# Altium schematic sheet Sheet1.SchDoc(Sheet1)
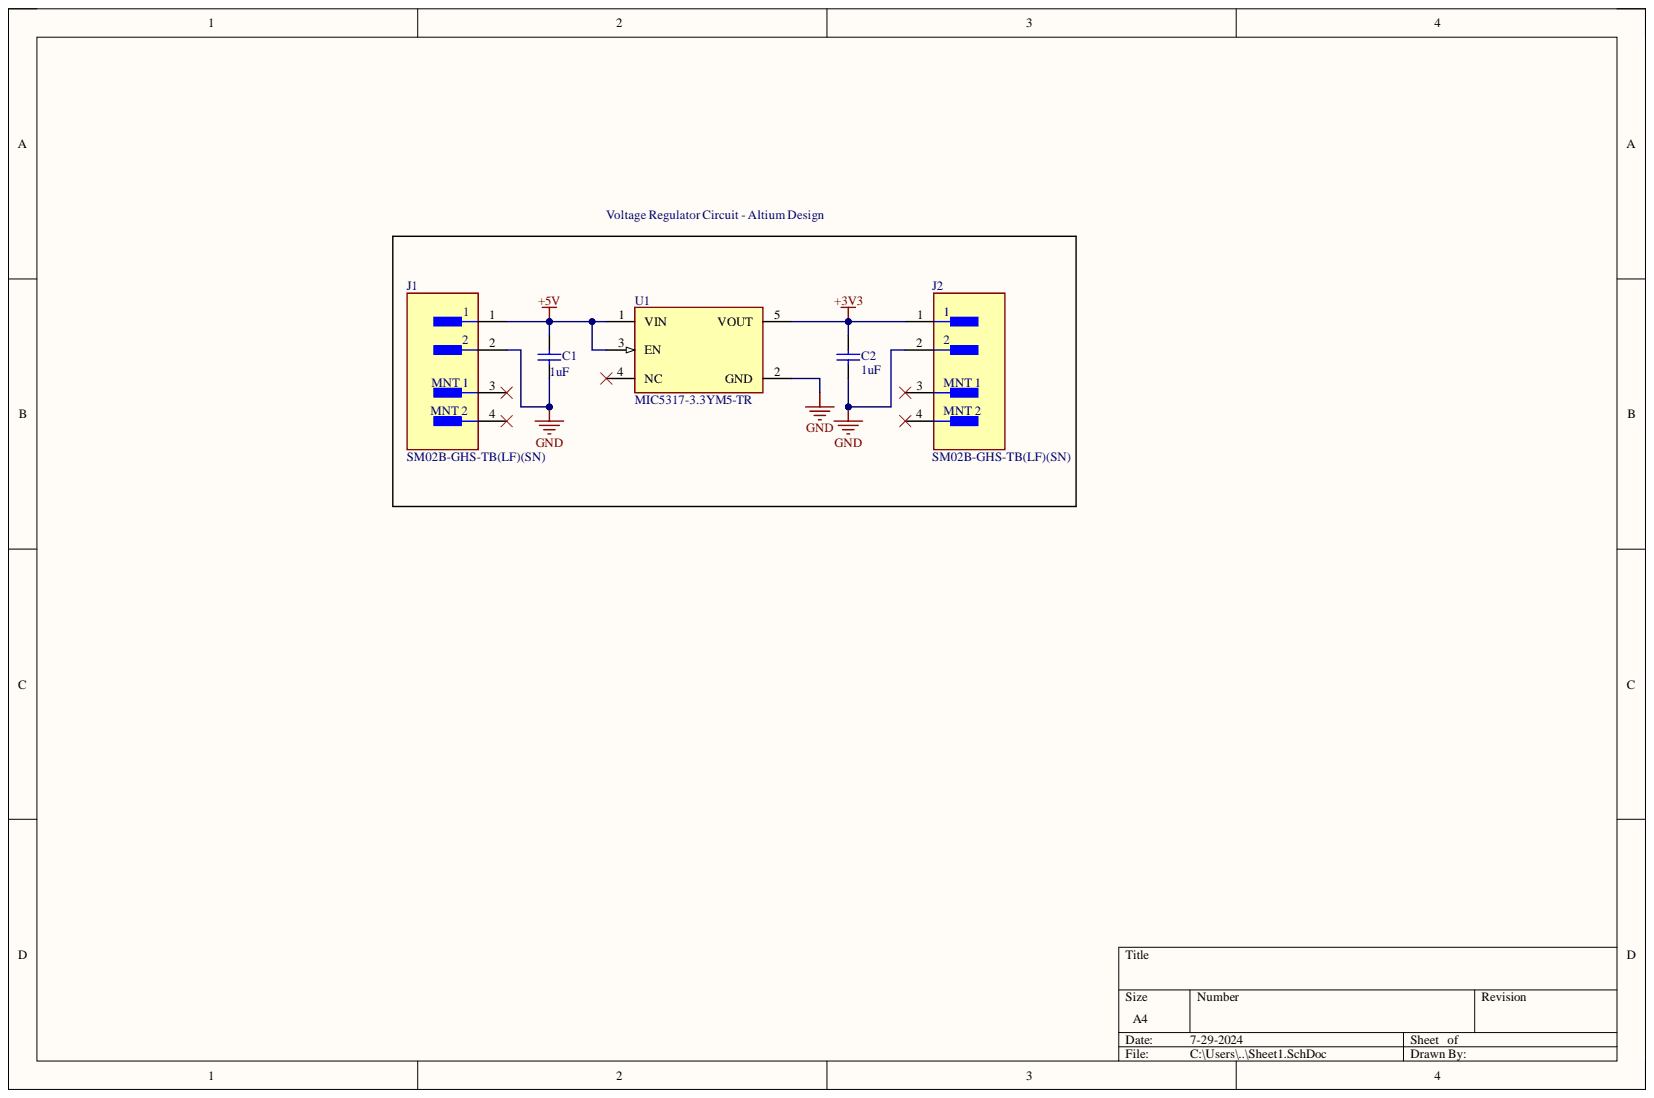
[diagram p0: Sheet1.SchDoc]

## Parts
C1  1uF  [CAPC2013X135X45LL10T25]
C2  1uF  [CAPC2013X135X45LL10T25]
J1  SM02B-GHS-TB(LF)(SN)  [FP-SM02B-GHS-TB_LF_SN-MFG]
J2  SM02B-GHS-TB(LF)(SN)  [FP-SM02B-GHS-TB_LF_SN-MFG]
U1  MIC5317-3.3YM5-TR  [FP-SOT23-5LD-PL-1-MFG]

## Nets
+3V3: C2.2 J2.1 U1.5(VOUT)
+5V: C1.2 J1.1 U1.1(VIN) U1.3(EN)
GND: C1.1 C2.1 J1.2 J2.2 U1.2(GND)

Not connected: J1 3 4; J2 3 4; U1 4(NC)

## Library data of the parts
C1 C2 = CMP-1036-04761-2: Manufacturer: Murata; Part Number: GRM21BR71H105KA12L; Component Kind: Standard; Library Name: Altium Content Vault
J1 J2 = CMP-2000-07076-2: CONN HEADER SMD R/A 2POS 1.25MM; Connector Type: Outer Shroud Contact; Current Rating: 1A; Number of Contacts: 2; Pitch: 0.049inch; Voltage Rating: 50V; Color: Natural; Component Kind: Standard; Contact Material: Bronze; Contact Plating: Tin; Contact Type: Male Pin; Fastening Type: Latch; Housing Color: Natural; Housing Material: Nylon; Lead Free: Lead Free; Library Name: Altium Content Vault; Mount: Surface Mount; Number of Positions: 2; Number of Rows: 1; Orientation: Right Angle; Package Quantity: 2500; Packaging: Tape and Reel; Series: GH; Termination: Solder
U1 = CMP-06324-000116-1: IC REG LINEAR 3.3V 150MA SOT23-5; Dropout Voltage: 155mV; Max Input Voltage: 6V; Min Current Limit: 200mA; Min Input Voltage: 2.5V; Number of Outputs: 1; Power Supply Rejection Ratio (PSRR): 70dB; Case/Package: SOT-23-5; Component Kind: Standard; Library Name: Altium Content Vault; Max Operating Temperature: 125°C; Min Operating Temperature: -40°C; Mount: Surface Mount; Number of Regulators: 1; Output Current: 150mA; Output Voltage: 3.3V; Package Quantity: 3000; Packaging: Tape and Reel; RoHS Compliant: No; Series: -
